# Altium schematic sheet SensorBoardShield_Actuator.SchDoc
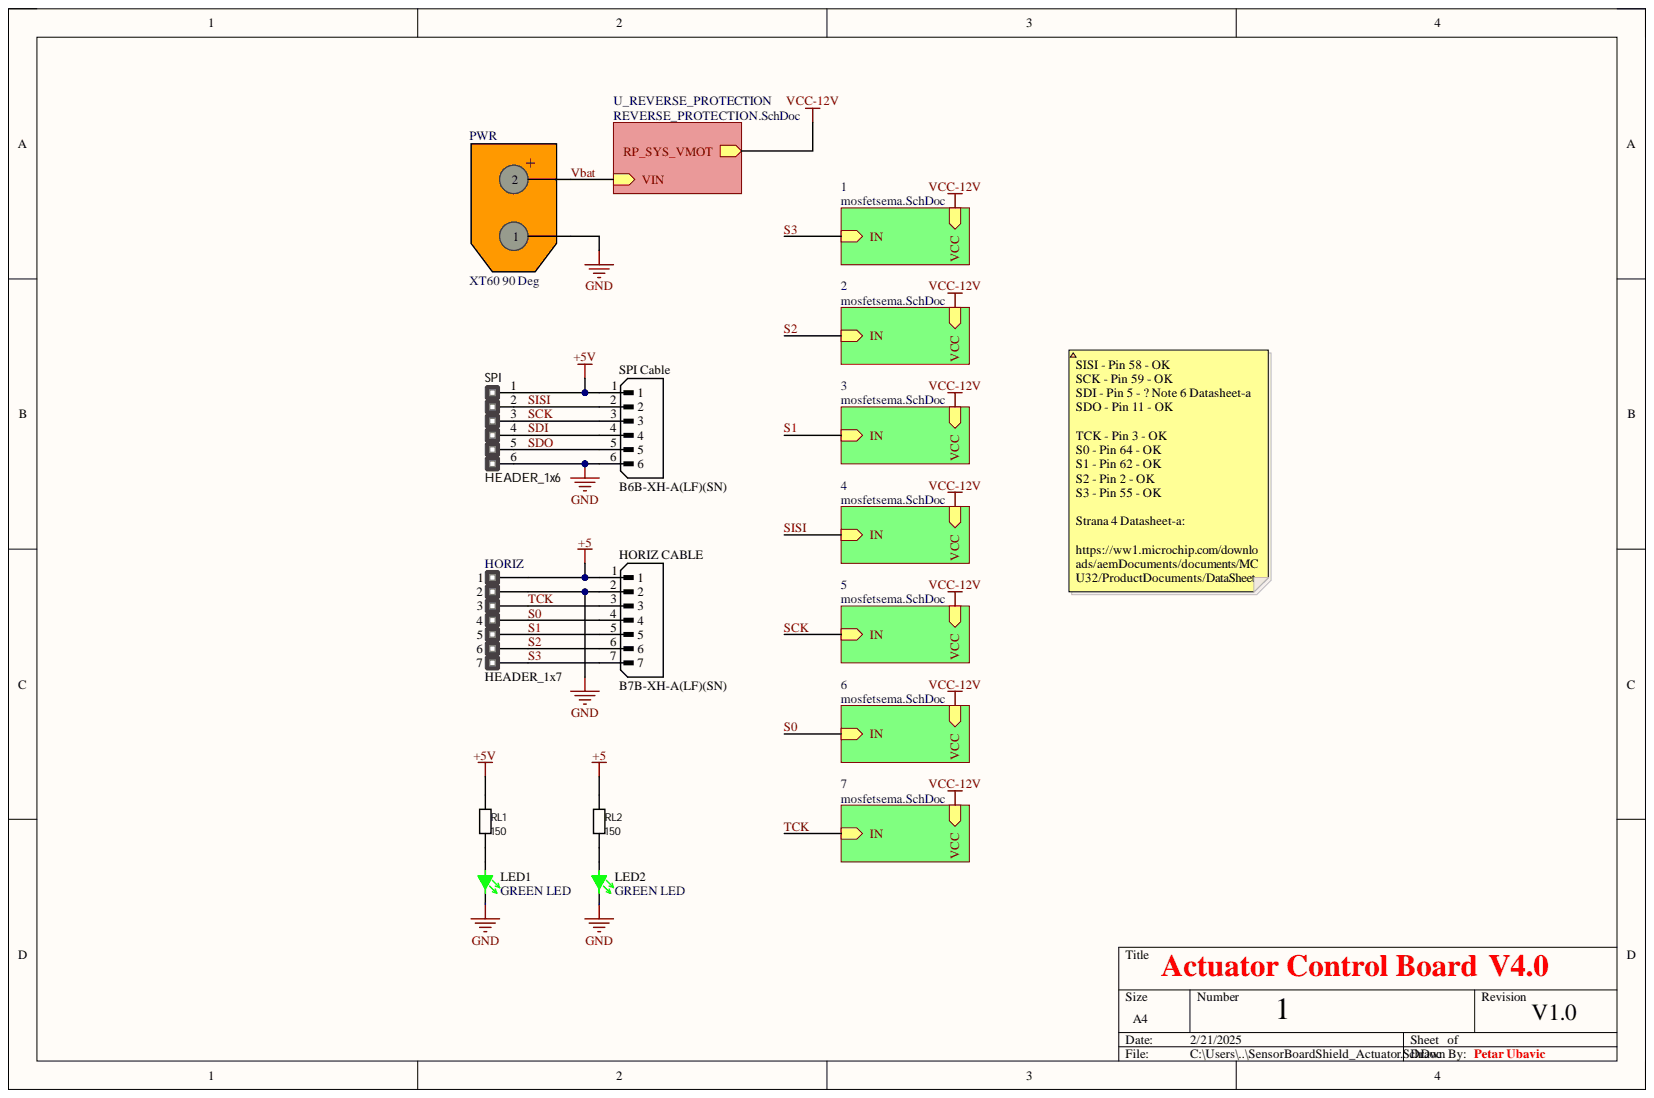
[diagram p0: SensorBoardShield_Actuator.SchDoc]

## Parts
HORIZ  HEADER_1x7  [HDR1X7]
HORIZ_CABLE  B7B-XH-A(LF)(SN)  [JST_B7B-XH-A(LF)(SN)]
LED1  GREEN LED  [DIOC1608X04N]
LED2  GREEN LED  [DIOC1608X04N]
PWR  XT60 90 Deg  [XT60PW-M]
RL1  150  [RES_0603]
RL2  150  [RES_0603]
SPI  HEADER_1x6  [HM1X6]
SPI_Cable  B6B-XH-A(LF)(SN)  [JST_B6B-XH-A(LF)(SN)]

## Nets
+5: HORIZ.1 HORIZ_CABLE.1 RL2.2
+5V: RL1.2 SPI.1 SPI_Cable.1
GND: HORIZ.2 HORIZ_CABLE.2 LED1.2 LED2.2 PWR.1 SPI.6 SPI_Cable.6
S0: HORIZ.4 HORIZ_CABLE.4
S1: HORIZ.5 HORIZ_CABLE.5
S2: HORIZ.6 HORIZ_CABLE.6
S3: HORIZ.7 HORIZ_CABLE.7
SCK: SPI.3 SPI_Cable.3
SDI: SPI.4 SPI_Cable.4
SDO: SPI.5 SPI_Cable.5
SISI: SPI.2 SPI_Cable.2
TCK: HORIZ.3 HORIZ_CABLE.3
Vbat: PWR.2
NetLED1_1: LED1.1 RL1.1
NetLED2_1: LED2.1 RL2.1
VCC-12V:

## Library data of the parts
HORIZ = Header 7: Header, 7-Pin; Component Kind: Standard; LatestRevisionDate: 17-Jul-2002; LatestRevisionNote: Re-released for DXP Platform.; Library Name: sensor_board.SCHLIB; Signal Integrity: Connector; Publisher: Altium Limited
HORIZ_CABLE = B7B-XH-A(LF)(SN): Connector Header Through Hole 7 position 0.098 (2.50mm); MF: JST Sales; Check_prices: https://www.snapeda.com/parts/B7B-XH-A%20(LF)(SN)/JST+Sales+America+Inc./view-part/?ref=eda; Component Kind: Standard; Library Name: B7B-XH-A(LF)(SN).SchLib; MP: B7B-XH-A (LF)(SN); Package: None; SnapEDA_Link: https://www.snapeda.com/parts/B7B-XH-A%20(LF)(SN)/JST+Sales+America+Inc./view-part/?ref=snap
LED1 LED2 = 00000001: LED GREEN DIFFUSED 0603 SMD; Component Kind: Standard; ComponentLink1Description: Digikey; ComponentLink1URL: https://www.digikey.com/en/products/detail/ams-osram-usa-inc/LG-Q396-PS-35/5249855; Library Name: Miscellaneous_Lib1.SchLib; Supplier 1: Avnet; Supplier Part Number 1: Q65111A3964
PWR = XT60PW-M: Component Kind: Standard; Description: XT60 90 Deg; Library Name: Connectors.IntLib
RL1 RL2 = R000004: RES 150 OHM 1% 1/10W 0603; resistance: 1Kohm; Component Kind: Standard; ComponentLink1Description: digikey; ComponentLink1URL: https://www.digikey.com/en/products/detail/vishay-beyschlag-draloric-bc-components/MCT06030C1001FP500/2607821; Library Name: ResistorsIntegrated.IntLib
SPI_Cable = B6B-XH-A(LF)(SN): Connector Header Through Hole 6 position 0.098 (2.50mm); MF: JST Sales; Check_prices: https://www.snapeda.com/parts/B6B-XH-A(LF)(SN)/JST+Sales+America+Inc./view-part/?ref=eda; Component Kind: Standard; Library Name: B6B-XH-A(LF)(SN).SchLib; MP: B6B-XH-A(LF)(SN); Package: None; SnapEDA_Link: https://www.snapeda.com/parts/B6B-XH-A(LF)(SN)/JST+Sales+America+Inc./view-part/?ref=snap
SPI = HEADER_1x6: Component Kind: Standard; Library Name: sensor_board.IntLib
